# Altium schematic sheet Debugger_Circuit.SchDoc(Debugger_Circuit)
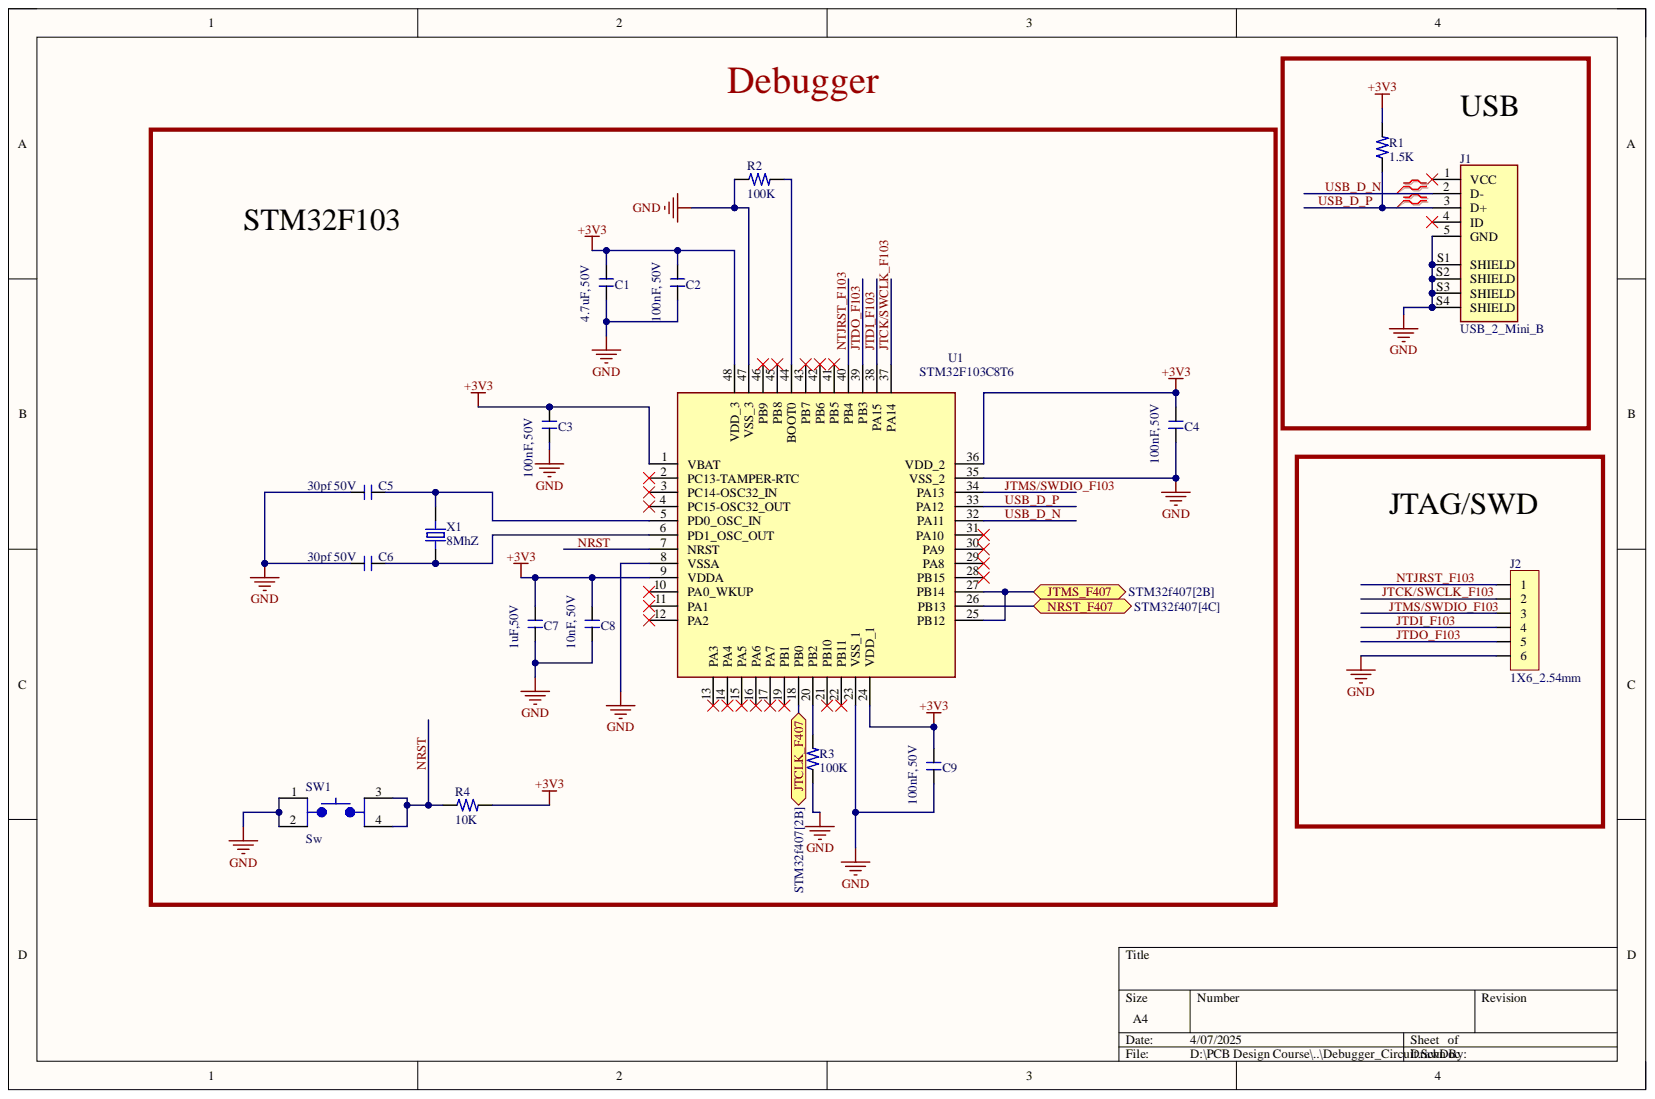
[diagram p0: Debugger_Circuit.SchDoc]

## Parts
C1  4.7uF, 50V  Voltage Rating: 50V  [Capacitor_0805]
C2  100nF, 50V  Voltage: 50V  [Capacitor_0805]
C3  100nF, 50V  Voltage: 50V  [Capacitor_0805]
C4  100nF, 50V  Voltage: 50V  [Capacitor_0805]
C5  30pf 50V  [Capacitor_0805]
C6  30pf 50V  [Capacitor_0805]
C7  1uF,50V  [Capacitor_0805]
C8  10nF, 50V  Voltage Rating (DC): 50V  [Capacitor_0805]
C9  100nF, 50V  Voltage: 50V  [Capacitor_0805]
J1  USB_2_Mini_B  [USB_2_Mini]
J2  1X6_2.54mm  [Header_1X6_2.54mm]
R1  1.5K  [Resistor_0805]
R2  100K  [Resistor_0603]
R3  100K  [Resistor_0603]
R4  10K  [Resistor_0603]
SW1  Sw  [SW_Tactile_SMD]
U1  STM32F103C8T6  [48-LQFP]
X1  8MhZ  [XTAL_8MhZ]

## Ports
JTCLK_F407, JTMS_F407, NRST_F407

## Nets
+3V3: C1.1 C2.2 C3.2 C4.2 C7.2 C8.2 C9.2 R1.2 R4.2 U1.1(VBAT) U1.9(VDDA) U1.24(VDD_1) U1.36(VDD_2) U1.48(VDD_3)
GND: C1.2 C2.1 C3.1 C4.1 C5.1 C6.1 C7.1 C8.1 C9.1 J1.5(GND) J1.S1(SHIELD) J1.S2(SHIELD) J1.S3(SHIELD) J1.S4(SHIELD) J2.6 R2.1 R3.1 SW1.1 SW1.2 U1.8(VSSA) U1.23(VSS_1) U1.35(VSS_2) U1.47(VSS_3)
JTCK/SWCLK_F103: J2.2 U1.37(PA14)
JTCLK_F407: U1.18(PB0) ; port JTCLK_F407
JTDI_F103: J2.4 U1.38(PA15)
JTDO_F103: J2.5 U1.39(PB3)
JTMS/SWDIO_F103: J2.3 U1.34(PA13)
JTMS_F407: U1.25(PB12) U1.27(PB14) ; port JTMS_F407
NRST: R4.1 SW1.3 SW1.4 U1.7(NRST)
NRST_F407: U1.26(PB13) ; port NRST_F407
NTJRST_F103: J2.1 U1.40(PB4)
USB_D_N: J1.2(D-) U1.32(PA11)
USB_D_P: J1.3(D+) R1.1 U1.33(PA12)
NetC5_2: C5.2 U1.5(PD0_OSC_IN) X1.2
NetC6_2: C6.2 U1.6(PD1_OSC_OUT) X1.1
NetR2_2: R2.2 U1.44(BOOT0)
NetR3_2: R3.2 U1.20(PB2)

Not connected: J1 1(VCC) 4(ID); U1 2(PC13-TAMPER-RTC) 3(PC14-OSC32_IN) 4(PC15-OSC32_OUT) 10(PA0_WKUP) 11(PA1) 12(PA2) 13(PA3) 14(PA4) 15(PA5) 16(PA6) 17(PA7) 19(PB1) 21(PB10) 22(PB11) 28(PB15) 29(PA8) 30(PA9) 31(PA10) 41(PB5) 42(PB6) 43(PB7) 45(PB8) 46(PB9)

## Library data of the parts
C1 = Cap_4.7uF_0805: Multilayer Ceramic Capacitor, 4.7 uF, 50 V, ï¿½ 10%, X5R, 0805 [2012 Metric]; Manufacturer: Murata; Manufacturer Part Number: GRM21BR61H475KE51L; Case Code (Imperial): 0805; Case Code (Metric): 2012; Case/Package: 0805; Category: Ceramic Capacitors; Component Kind: Standard; ComponentLink1Description: http://www.farnell.com/datasheets/2079186.pdf?src-supplier=Farnell; ComponentLink1URL: http://www.farnell.com/datasheets/2079186.pdf?src-supplier=Farnell; ComponentLink2Description: https://datasheet.octopart.com/GRM21BR61H475KE51L-Murata-datasheet-22122223.pdf?src-supplier=Future+Electronics; ComponentLink2URL: https://datasheet.octopart.com/GRM21BR61H475KE51L-Murata-datasheet-22122223.pdf?src-supplier=Future+Electronics; ComponentLink3Description: http://www.farnell.com/datasheets/2079186.pdf?src-supplier=Element14; ComponentLink3URL: http://www.farnell.com/datasheets/2079186.pdf?src-supplier=Element14; ComponentLink4Description: https://datasheet.octopart.com/GRM21BR61H475KE51L-Murata-datasheet-66077112.pdf?src-supplier=Element14; ComponentLink4URL: https://datasheet.octopart.com/GRM21BR61H475KE51L-Murata-datasheet-66077112.pdf?src-supplier=Element14; ComponentLink5Description: http://search.murata.co.jp/Ceramy/image/img/A01X/G101/ENG/GRM21BR61H475KE51-01.pdf?src-supplier=Digikey; ComponentLink5URL: http://search.murata.co.jp/Ceramy/image/img/A01X/G101/ENG/GRM21BR61H475KE51-01.pdf?src-supplier=Digikey; ComponentLink6Description: https://search.murata.co.jp/Ceramy/image/img/A01X/G101/ENG/GRM21BR61H475KE51-01.pdf?src-supplier=Digikey; ComponentLink6URL: https://search.murata.co.jp/Ceramy/image/img/A01X/G101/ENG/GRM21BR61H475KE51-01.pdf?src-supplier=Digikey; Depth: 1.25mm; Dielectric: X5R; Height: 1.25mm; Lead Free: 5697LeadFree; Length: 2mm; Material: Ceramic; Max Operating Temperature: 85°C; Min Operating Temperature: -55°C; Mounting Technology: Surface Mount; Packaging: Tape and Reel; Pricing: 1=0,26, 10=0,141, 50=0,141, 100=0,086, 1000=0,083, 10000=0,071 (USD); Reach SVHC Compliant: No; RoHS: Yes; RoHS Compliant: Yes; Series: GRM; Stock: 172250; Supplier: Mouser; Supplier Part Number: 81-GRM21BR61H475KE1L; Thickness: 0.055inch; Tolerance: 10%; Value: 4.7uF; Voltage Rating (DC): 50V; Width: 0.049inch; Library Name: Schematics_Library_Hanif.SchLib
C2-C4 C9 = Cap_100nF_0805: Multilayer Ceramic Capacitor, 0.1 uF, 50 V, ï¿½ 10%, X7R, 0805 [2012 Metric]; Manufacturer: Samsung; Manufacturer Part Number: CL21B104KBCNNNC; Case Code (Imperial): 0805; Case Code (Metric): 2012; Case/Package: 0805; Category: Ceramic Capacitors; Component Kind: Standard; ComponentLink1Description: https://datasheet.ciiva.com/25710/cl21b104kbcnnnc-25710182.pdf?src-supplier=Digikey; ComponentLink1URL: https://datasheet.ciiva.com/25710/cl21b104kbcnnnc-25710182.pdf?src-supplier=Digikey; ComponentLink2Description: https://datasheet.ciiva.com/29199/454152-da-01-en-vs-kondensator-0805-29199814.pdf?src-supplier=Conrad; ComponentLink2URL: https://datasheet.ciiva.com/29199/454152-da-01-en-vs-kondensator-0805-29199814.pdf?src-supplier=Conrad; Construction: Flat; Depth: 1.25mm; Dielectric: X7R; Height: 0.85mm; Lead Free: 5697LeadFree; Length: 2mm; Material: Ceramic; Max Operating Temperature: 125°C; Min Operating Temperature: -55°C; Mounting Technology: Surface Mount; Packaging: Tape and Reel; Pricing: 1=0,08, 10=0,012, 100=0,0104, 1000=0,008 (USD); Radiation Hardening: No; RoHS: Yes; RoHS Compliant: Yes; Series: CL; Stock: 674609; Supplier: Digikey; Supplier Part Number: 1276-1003-1-ND; Thickness: 0.037inch; Tolerance: 10%; Value: 100nF; Voltage Rating: 50V; Voltage Rating (DC): 50V; Width: 0.049inch; Library Name: Schematics_Library_Hanif.SchLib
C5 C6 = Cap_30pf_0805: Capacitor, ceramic, 30Pf, 50Vdc, 10-% Tol, 10+% Tol, c0G-Tc Code, -30, 30Ppm-Tc Rohs Compliant: Yes; Manufacturer: KEMET; Manufacturer Part Number: C0805C300K5GACTU; Category: Ceramic Capacitors; Component Kind: Standard; ComponentLink1Description: http://www.mouser.com/ds/2/212/KEM_C1003_C0G_SMD-1101588.pdf?src-supplier=Mouser; ComponentLink1URL: http://www.mouser.com/ds/2/212/KEM_C1003_C0G_SMD-1101588.pdf?src-supplier=Mouser; ComponentLink2Description: http://components.arrow.com/part/dataview/2091985S8614748N7429?src-supplier=Arrow+Electronics; ComponentLink2URL: http://components.arrow.com/part/dataview/2091985S8614748N7429?src-supplier=Arrow+Electronics; ComponentLink3Description: https://datasheet.octopart.com/C0805C300K5GACTU-KEMET-datasheet-149535491.pdf?src-supplier=Farnell; ComponentLink3URL: https://datasheet.octopart.com/C0805C300K5GACTU-KEMET-datasheet-149535491.pdf?src-supplier=Farnell; ComponentLink4Description: https://search.kemet.com/component-edge/download/datasheet/C0805C300K5GACTU.pdf?src-supplier=Digikey; ComponentLink4URL: https://search.kemet.com/component-edge/download/datasheet/C0805C300K5GACTU.pdf?src-supplier=Digikey; Pricing: 1=0,27, 10=0,164, 50=0,164, 100=0,096, 1000=0,077, 10000=0,047 (USD); RoHS: Yes; Stock: 2572; Supplier: Mouser; Supplier Part Number: 80-C0805C300K5G; Library Name: Schematics_Library_Hanif.SchLib
C7 = Cap_1uF_0805: 0805 1 uF 50 V ±5 % Tolerance X7R Surface Mount Multilayer Ceramic Capacitor; Manufacturer: Yageo; Manufacturer Part Number: CC0805JKX7R9BB105; Dielectric: 1511DielectricType; Material: 4241ConductorMaterial; Category: Ceramic Capacitors; Component Kind: Standard; ComponentLink1Description: https://datasheet.octopart.com/CC0805JKX7R9BB105-Yageo-datasheet-137700082.pdf?src-supplier=Future+Electronics; ComponentLink1URL: https://datasheet.octopart.com/CC0805JKX7R9BB105-Yageo-datasheet-137700082.pdf?src-supplier=Future+Electronics; ComponentLink2Description: http://www.yageo.com/documents/recent/UPY-GPHC_X7R_6.3V-to-50V_18.pdf?src-supplier=Digikey; ComponentLink2URL: http://www.yageo.com/documents/recent/UPY-GPHC_X7R_6.3V-to-50V_18.pdf?src-supplier=Digikey; ComponentLink3Description: https://datasheet.octopart.com/CC0805JKX7R9BB105-Yageo-datasheet-151948686.pdf?src-supplier=Farnell; ComponentLink3URL: https://datasheet.octopart.com/CC0805JKX7R9BB105-Yageo-datasheet-151948686.pdf?src-supplier=Farnell; Halogen Free: 5694HalogenFree; Height: 1.45mm; Lead Free: 5697LeadFree; Pricing: 1=0,29, 10=0,168, 100=0,1077, 1000=0,07673 (USD); RoHS: Yes; Stock: 30160; Supplier: Digikey; Supplier Part Number: 311-3498-1-ND; Voltage Rating (DC): 50V; Library Name: Schematics_Library_Hanif.SchLib
C8 = Cap_10nF_0805: Multilayer Ceramic Capacitors MLCC - SMD/SMT 50V 0.01uF X7R 0805 10% AEC-Q200; Manufacturer: KEMET; Manufacturer Part Number: C0805C103K5RECAUTO; Dielectric: 1511DielectricType; Category: Ceramic Capacitors; Component Kind: Standard; ComponentLink1Description: http://www.farnell.com/datasheets/2723804.pdf?src-supplier=Farnell; ComponentLink1URL: http://www.farnell.com/datasheets/2723804.pdf?src-supplier=Farnell; ComponentLink2Description: https://api.kemet.com/component-edge/download/datasheet/C0805C103K5RECAUTO.pdf?src-supplier=Digikey; ComponentLink2URL: https://api.kemet.com/component-edge/download/datasheet/C0805C103K5RECAUTO.pdf?src-supplier=Digikey; ComponentLink3Description: http://www.farnell.com/datasheets/2723804.pdf?src-supplier=Newark; ComponentLink3URL: http://www.farnell.com/datasheets/2723804.pdf?src-supplier=Newark; Pricing: 1=0,22, 10=0,0376 (USD); RoHS: Yes; Stock: 16; Supplier: Arrow Electronics; Supplier Part Number: C0805C103K5RECAUTO; Library Name: Schematics_Library_Hanif.SchLib
J1 = USB2_Mini_690-B_005-299-043: Conn Mini USB 2.0 Type B RCP 5 POS 0.8mm Solder RA SMD 5 Terminal 1 Port; Manufacturer: EDAC; Manufacturer Part Number: 690-005-299-043; Current Rating: 1A; Gender: Receptacle; Mating Cycles: 1500; Number of Contacts: 5; Number of Ports: 1; Shielding: Unshielded; USB Standard: 6108USBStandard; Category: USB Connectors; Color: Black; Component Kind: Standard; ComponentLink1Description: https://datasheet.ciiva.com/30246/690-005-299-043-30246515.pdf?src-supplier=Onlinecomponents.com; ComponentLink1URL: https://datasheet.ciiva.com/30246/690-005-299-043-30246515.pdf?src-supplier=Onlinecomponents.com; ComponentLink2Description: https://datasheet.ciiva.com/29660/690-005-299-043-29660027.pdf?src-supplier=Digikey; ComponentLink2URL: https://datasheet.ciiva.com/29660/690-005-299-043-29660027.pdf?src-supplier=Digikey; ComponentLink3Description: https://datasheet.ciiva.com/29660/690-005-299-043-29660028.pdf?src-supplier=Digikey; ComponentLink3URL: https://datasheet.ciiva.com/29660/690-005-299-043-29660028.pdf?src-supplier=Digikey; ComponentLink4Description: https://datasheet.ciiva.com/29660/690-005-299-043-29660029.pdf?src-supplier=Digikey; ComponentLink4URL: https://datasheet.ciiva.com/29660/690-005-299-043-29660029.pdf?src-supplier=Digikey; Connector Type: USB; Contact Material: Bronze; Contact Plating: Gold; Flammability Rating: UL94 V-0; Height: 3.98mm; Housing Material: Thermoplastic; Insulation Color: Black; Lead Free: Lead Free; Max Operating Temperature: 80°C; Min Operating Temperature: -30°C; Mounting Technology: Surface Mount,Horizontal; Orientation: Right Angle; Packaging: Cut Tape; Pitch: 0.8mm; Pricing: 1=0,56, 10=0,475, 25=0,4452, 50=0,4238, 100=0,4036, 250=0,37828, 500=0,3602 (USD); RoHS: No; Series: 690; Stock: 51741; Supplier: Digikey; Supplier Part Number: 151-1206-1-ND; Termination: Solder; Library Name: Schematics_Library_Hanif.SchLib
J2 = Header_Male_1X6_2.54mm: KK 254 Wire-to-Board Header Single Row Vertical 6 Circuits PA Polyamide Nylon Gold Plating; Manufacturer: Molex; Contact Resistance: 10mR; Current Rating: 4A; Gender: Male; Insulation Resistance: 50GR; Number of Circuits: 6; Pitch: 2.54mm; Voltage Rating: 250V; Category: Headers and Wire Housings; Color: White; Component Kind: Standard; ComponentLink1Description: https://datasheet.ciiva.com/14803/304930022102061-pcb-headers-14803678.pdf?src-supplier=Arrow+Electronics; ComponentLink1URL: https://datasheet.ciiva.com/14803/304930022102061-pcb-headers-14803678.pdf?src-supplier=Arrow+Electronics; ComponentLink2Description: https://datasheet.ciiva.com/19461/1965971-19461525.pdf?src-supplier=Jameco; ComponentLink2URL: https://datasheet.ciiva.com/19461/1965971-19461525.pdf?src-supplier=Jameco; ComponentLink3Description: https://datasheet.ciiva.com/10086/0022102061-pcb-headers-10086323.pdf?src-supplier=Avnet; ComponentLink3URL: https://datasheet.ciiva.com/10086/0022102061-pcb-headers-10086323.pdf?src-supplier=Avnet; ComponentLink4Description: https://datasheet.ciiva.com/30196/0022102061-pcb-headers-30196683.pdf?src-supplier=Onlinecomponents.com; ComponentLink4URL: https://datasheet.ciiva.com/30196/0022102061-pcb-headers-30196683.pdf?src-supplier=Onlinecomponents.com; Contact Material: Brass; Contact Mating Length: 0.295inch; Contact Plating: Gold,Copper,Tin; Contact Type: Male Pin; Depth: 9.02mm; Flammability Rating: UL94 V-0; Height: 14.68mm; Housing Color: Black; Housing Material: Nylon; Length: 95mm; Manufacturer Part Number: 22-10-2061; Max Operating Temperature: 75°C; Min Operating Temperature: 0°C; Mounting Technology: Through Hole; Number of Contacts: 6; Number of Positions: 6; Number of Rows: 1; Orientation: Straight; Package Quantity: 10; Packaging: Bulk; Pricing: 1=1,07, 10=0,873, 50=0,873, 100=0,711, 1000=0,65, 10000=0,65 (USD); Radiation Hardening: No; Reach SVHC Compliant: Unknown; RoHS: Yes; RoHS Compliant: Yes; Series: KK® 4030; Stock: 2052; Supplier: Mouser; Supplier Part Number: 538-22-10-2061; Termination: Solder; Voltage Rating (DC): 250V; Library Name: Schematics_Library_Hanif.SchLib
R1 = Res_1.5K0805: Res Thick Film 0805 1.5K Ohm 1% 0.125W(1/8W) ±100ppm/C Pad SMD T/R; Manufacturer: TE Connectivity; Manufacturer Part Number: CRG0805F1K5; Max Power Dissipation: 125mW; Number of Resistors: 1; Operating Supply Voltage: 150V; Temperature Coefficient: 100ppm/°C; Value: 1.5kR; Case Code (Imperial): 0805; Case Code (Metric): 2012; Case/Package: 0805; Category: Chip SMD Resistors; Component Kind: Standard; ComponentLink1Description: https://datasheet.ciiva.com/11810/0900766b800a696e-11810424.pdf?src-supplier=Rs; ComponentLink1URL: https://datasheet.ciiva.com/11810/0900766b800a696e-11810424.pdf?src-supplier=Rs; ComponentLink2Description: https://datasheet.ciiva.com/30131/eng-ds-1773204-e-30131519.pdf?src-supplier=Onlinecomponents.com; ComponentLink2URL: https://datasheet.ciiva.com/30131/eng-ds-1773204-e-30131519.pdf?src-supplier=Onlinecomponents.com; ComponentLink3Description: https://datasheet.ciiva.com/16758/70063020-16758589.pdf?src-supplier=Allied+Electronics; ComponentLink3URL: https://datasheet.ciiva.com/16758/70063020-16758589.pdf?src-supplier=Allied+Electronics; Depth: 1.25mm; ELV: Compliant; Height: 0.55mm; Length: 2mm; Max Operating Temperature: 125°C; Military Standard: Not; Min Operating Temperature: -55°C; Mounting Technology: Surface Mount; Number of Terminations: 2; Package Quantity: 5000; Packaging: Tape and Reel; Power Rating: 125mW; Pricing: 1=0,1, 10=0,036, 100=0,0183, 1000=0,01059, 2500=0,00888 (USD); Resistor Type: Thick Film; RoHS: Yes; RoHS Compliant: Yes; Series: CRG; Stock: 45629; Supplier: Digikey; Supplier Part Number: A106057CT-ND; Technology: THICK FILM; Termination: Solder; Tolerance: 1%; Voltage Rating: 150V; Weight: 0.000194oz; Width: 1.25mm; Library Name: Schematics_Library_Hanif.SchLib
R2 R3 = R_100K_0603: Res Thick Film 0603 100K Ohm 1% 0.1W(1/10W) ±100ppm/C Pad SMD Automotive T/R; Manufacturer: Stackpole Electronics; Manufacturer Part Number: RMCF0603FT100K; Max Power Dissipation: 100mW; Temperature Coefficient: 100ppm/°C; Value: 100kR; Voltage Rating: 75V; Case Code (Imperial): 0603; Case Code (Metric): 1608; Case/Package: 0603; Category: Chip SMD Resistors; Component Kind: Standard; ComponentLink1Description: https://datasheet.ciiva.com/15193/getdatasheetpartid-362597-15193437.pdf?src-supplier=Verical; ComponentLink1URL: https://datasheet.ciiva.com/15193/getdatasheetpartid-362597-15193437.pdf?src-supplier=Verical; Construction: Rectangular; Contact Plating: Tin,Matte; Depth: 0.8mm; Features: Automotive AEC-Q200; Height: 0.022inch; Lead Free: 5697LeadFree; Length: 1.55mm; Max Operating Temperature: 155°C; Military Standard: Not; Min Operating Temperature: -55°C; Mounting Technology: Surface Mount; Number of Terminations: 2; Packaging: Tape and Reel; Pricing: 1=0,1, 10=0,02, 100=0,0091, 1000=0,0048, 2500=0,00386 (USD); Radiation Hardening: No; RoHS: Yes; RoHS Compliant: Yes; Series: RMCF; Stock: 722640; Supplier: Digikey; Supplier Part Number: RMCF0603FT100KCT-ND; Tolerance: 1%; Width: 0.031inch; Library Name: Schematics_Library_Hanif.SchLib
R4 = R_10K_0603: SMD Chip Resistor, 10 kOhm, ï¿½ 1%, 100 mW, 0603 [1608 Metric], Thick Film, General Purpose; Manufacturer: Yageo; Manufacturer Part Number: RC0603FR-0710KL; Max Power Dissipation: 100mW; Temperature Coefficient: 100ppm/°C; Value: 10kR; Voltage Rating: 75V; Voltage Rating (DC): 50V; Case Code (Imperial): 0603; Case Code (Metric): 1608; Case/Package: 0603; Category: Chip SMD Resistors; Component Kind: Standard; ComponentLink1Description: https://datasheet.ciiva.com/7660/38590-7660789.pdf?src-supplier=Element14; ComponentLink1URL: https://datasheet.ciiva.com/7660/38590-7660789.pdf?src-supplier=Element14; ComponentLink2Description: https://datasheet.ciiva.com/7983/443971-7983596.pdf?src-supplier=Element14; ComponentLink2URL: https://datasheet.ciiva.com/7983/443971-7983596.pdf?src-supplier=Element14; ComponentLink3Description: https://datasheet.ciiva.com/22747/443971-22747519.pdf?src-supplier=Element14; ComponentLink3URL: https://datasheet.ciiva.com/22747/443971-22747519.pdf?src-supplier=Element14; ComponentLink4Description: https://datasheet.ciiva.com/46440/1868787-46440891.pdf?src-supplier=Element14; ComponentLink4URL: https://datasheet.ciiva.com/46440/1868787-46440891.pdf?src-supplier=Element14; ComponentLink5Description: https://datasheet.ciiva.com/7746/1738695-7746239.pdf?src-supplier=Element14; ComponentLink5URL: https://datasheet.ciiva.com/7746/1738695-7746239.pdf?src-supplier=Element14; ComponentLink6Description: https://datasheet.ciiva.com/15189/getdatasheetpartid-409289-15189591.pdf?src-supplier=Verical; ComponentLink6URL: https://datasheet.ciiva.com/15189/getdatasheetpartid-409289-15189591.pdf?src-supplier=Verical; Construction: Rectangular; Depth: 0.8mm; Features: Moisture Resistant; Height: 0.45mm; Lead Free: 5697LeadFree; Lead Pitch: 0.3mm; Length: 1.6mm; Max Operating Temperature: 155°C; Military Standard: Not; Min Operating Temperature: -55°C; Number of Terminations: 2; Packaging: Tape and Reel; Pins: 2; Power Rating: 100mW; Pricing: 1=0,1, 10=0,009, 100=0,0071, 1000=0,00612 (USD); Reach SVHC Compliant: No; Resistance Tolerance: 1%; Resistor Type: Thick Film; RoHS: Yes; RoHS Compliant: Yes; Series: RC; Stock: 2808060; Supplier: Digikey; Supplier Part Number: 311-10.0KHRCT-ND; Termination: SMD/SMT; Tolerance: 1%; Weight: 7.1E-05oz; Width: 0.8mm; Library Name: Schematics_Library_Hanif.SchLib
SW1 = SW_Tactile_SPST: TL3305A Series SPST 12 V 4.5 x 4.5 mm 260 gf Top Actuated SMT Tactile Switch; Manufacturer: E-Switch; Manufacturer Part Number: TL3305AF260QG; Actuator Type: Standard; Illumination Type: Non-Illuminated; Mechanical Life: 200000Cycles; Operating Force: 260gf; Switch Function: Off-Mom; Switch Travel: 0.2mm; Category: Tactile Switches; Component Kind: Standard; ComponentLink1Description: http://www.farnell.com/datasheets/2554191.pdf?src-supplier=Farnell; ComponentLink1URL: http://www.farnell.com/datasheets/2554191.pdf?src-supplier=Farnell; ComponentLink2Description: http://www.farnell.com/datasheets/2554191.pdf?src-supplier=Newark; ComponentLink2URL: http://www.farnell.com/datasheets/2554191.pdf?src-supplier=Newark; ComponentLink3Description: http://spec_sheets.e-switch.com/specs/P010471.pdf?src-supplier=Mouser; ComponentLink3URL: http://spec_sheets.e-switch.com/specs/P010471.pdf?src-supplier=Mouser; ComponentLink4Description: http://www.farnell.com/datasheets/2554191.pdf?src-supplier=Element14; ComponentLink4URL: http://www.farnell.com/datasheets/2554191.pdf?src-supplier=Element14; ComponentLink5Description: http://spec_sheets.e-switch.com/specs/P010471.pdf?src-supplier=Digikey; ComponentLink5URL: http://spec_sheets.e-switch.com/specs/P010471.pdf?src-supplier=Digikey; ComponentLink6Description: http://spec_sheets.e-switch.com/specs/P010471.pdf?src-supplier=NAC+Semi; ComponentLink6URL: http://spec_sheets.e-switch.com/specs/P010471.pdf?src-supplier=NAC+Semi; Lead Free: Lead Free; Max Operating Temperature: 70°C; Min Operating Temperature: -20°C; Mounting Technology: Surface Mount; Packaging: Cut Tape; Pricing: 1=0,2, 10=0,176, 25=0,1664, 100=0,153, 250=0,14456, 500=0,1385, 1000=0,13264 (USD); RoHS: No; Series: TL3305; Stock: 97166; Supplier: Digikey; Supplier Part Number: EG5353CT-ND; Termination: Gull Wing; Throw Configuration: SPST; Library Name: Schematics_Library_Hanif.SchLib
U1 = MCU_STM32F103C8T6: ARM Cortex-M3 STM32 F1 Microcontroller 32-Bit 72MHz 64kB FLASH LQFP48 STM32F103C8T6; Manufacturer: STMicroelectronics; Data Bus Width: 32b; Interface: I2C,SPI,USB,CAN,USART; Max Supply Voltage: 3.6V; Min Supply Voltage: 2V; Nominal Supply Current: 1.7uA; Number of A/D Converters: 2NUMBER; Number of ADC Channels: 10; Number of Channels: 10NUMBER; Number of I/Os: 37; Number of Timers/Counters: 3; RAM Size: 20KB; Case/Package: LQFP; Category: Microcontrollers; Clock Frequency: 72MHz; Component Kind: Standard; ComponentLink1Description: https://datasheet.ciiva.com/15122/getdatasheetpartid-69880-15122076.pdf?src-supplier=Verical; ComponentLink1URL: https://datasheet.ciiva.com/15122/getdatasheetpartid-69880-15122076.pdf?src-supplier=Verical; ComponentLink2Description: https://datasheet.ciiva.com/14100/pustm-arm-microcont-stm32f103-en-14100508.pdf?src-supplier=Distrelec; ComponentLink2URL: https://datasheet.ciiva.com/14100/pustm-arm-microcont-stm32f103-en-14100508.pdf?src-supplier=Distrelec; ComponentLink3Description: https://datasheet.ciiva.com/16210/cd00161566-16210248.pdf?src-supplier=Future+Electronics; ComponentLink3URL: https://datasheet.ciiva.com/16210/cd00161566-16210248.pdf?src-supplier=Future+Electronics; ComponentLink4Description: https://datasheet.ciiva.com/8054/1730126-8054942.pdf?src-supplier=Element14; ComponentLink4URL: https://datasheet.ciiva.com/8054/1730126-8054942.pdf?src-supplier=Element14; ComponentLink5Description: https://datasheet.ciiva.com/48687/001075658-da-01-en-mcu-64kb-mem-stm32f103c8t6-lqfp-48-stm-48687589.pdf?src-supplier=Conrad; ComponentLink5URL: https://datasheet.ciiva.com/48687/001075658-da-01-en-mcu-64kb-mem-stm32f103c8t6-lqfp-48-stm-48687589.pdf?src-supplier=Conrad; Core Architecture: ARM; Height: 1.4mm; Lead Free: 5697LeadFree; Length: 7mm; Manufacturer Part Number: STM32F103C8T6; Max Operating Temperature: 85°C; Memory Format: FLASH; Memory Size: 64KB; Min Operating Temperature: -40°C; Package Quantity: 1500; Packaging: Tray; Pins: 48; Pricing: 1=2,2959, 10=2,163, 25=2,0371, 50=2,029, 100=2,0223, 250=1,8714 (USD); RoHS: Yes; RoHS Compliant: Yes; Series: STM32F103C8; Stock: 1121974; Supplier: Arrow Electronics; Supplier Part Number: STM32F103C8T6; Weight: 0.006349oz; Width: 7mm; Library Name: Schematics_Library_Hanif.SchLib
X1 = Xtal_8MHz: Crystal 8MHz ±30ppm (Tol) ±50ppm (Stability) 32pF FUND 60Ohm 2-Pin HC-49/USX SMD T/R; Manufacturer: ECS International; Manufacturer Part Number: ECS-80-32-5PX-TR; Category: Crystals; Component Kind: Standard; ComponentLink1Description: https://datasheet.ciiva.com/852/csm-7x-852413.pdf?src-supplier=Digikey; ComponentLink1URL: https://datasheet.ciiva.com/852/csm-7x-852413.pdf?src-supplier=Digikey; ComponentLink2Description: https://datasheet.ciiva.com/852/csm-7x-852414.pdf?src-supplier=Digikey; ComponentLink2URL: https://datasheet.ciiva.com/852/csm-7x-852414.pdf?src-supplier=Digikey; ComponentLink3Description: https://datasheet.ciiva.com/852/csm-7x-852415.pdf?src-supplier=Digikey; ComponentLink3URL: https://datasheet.ciiva.com/852/csm-7x-852415.pdf?src-supplier=Digikey; Pricing: 1=0,3, 10=0,259, 50=0,235, 100=0,2252, 500=0,20416 (USD); RoHS: Yes; Stock: 6065; Supplier: Digikey; Supplier Part Number: XC1272CT-ND; Library Name: Schematics_Library_Hanif.SchLib
